# Altium schematic sheet CITest Board_v3.1_A.SchDoc
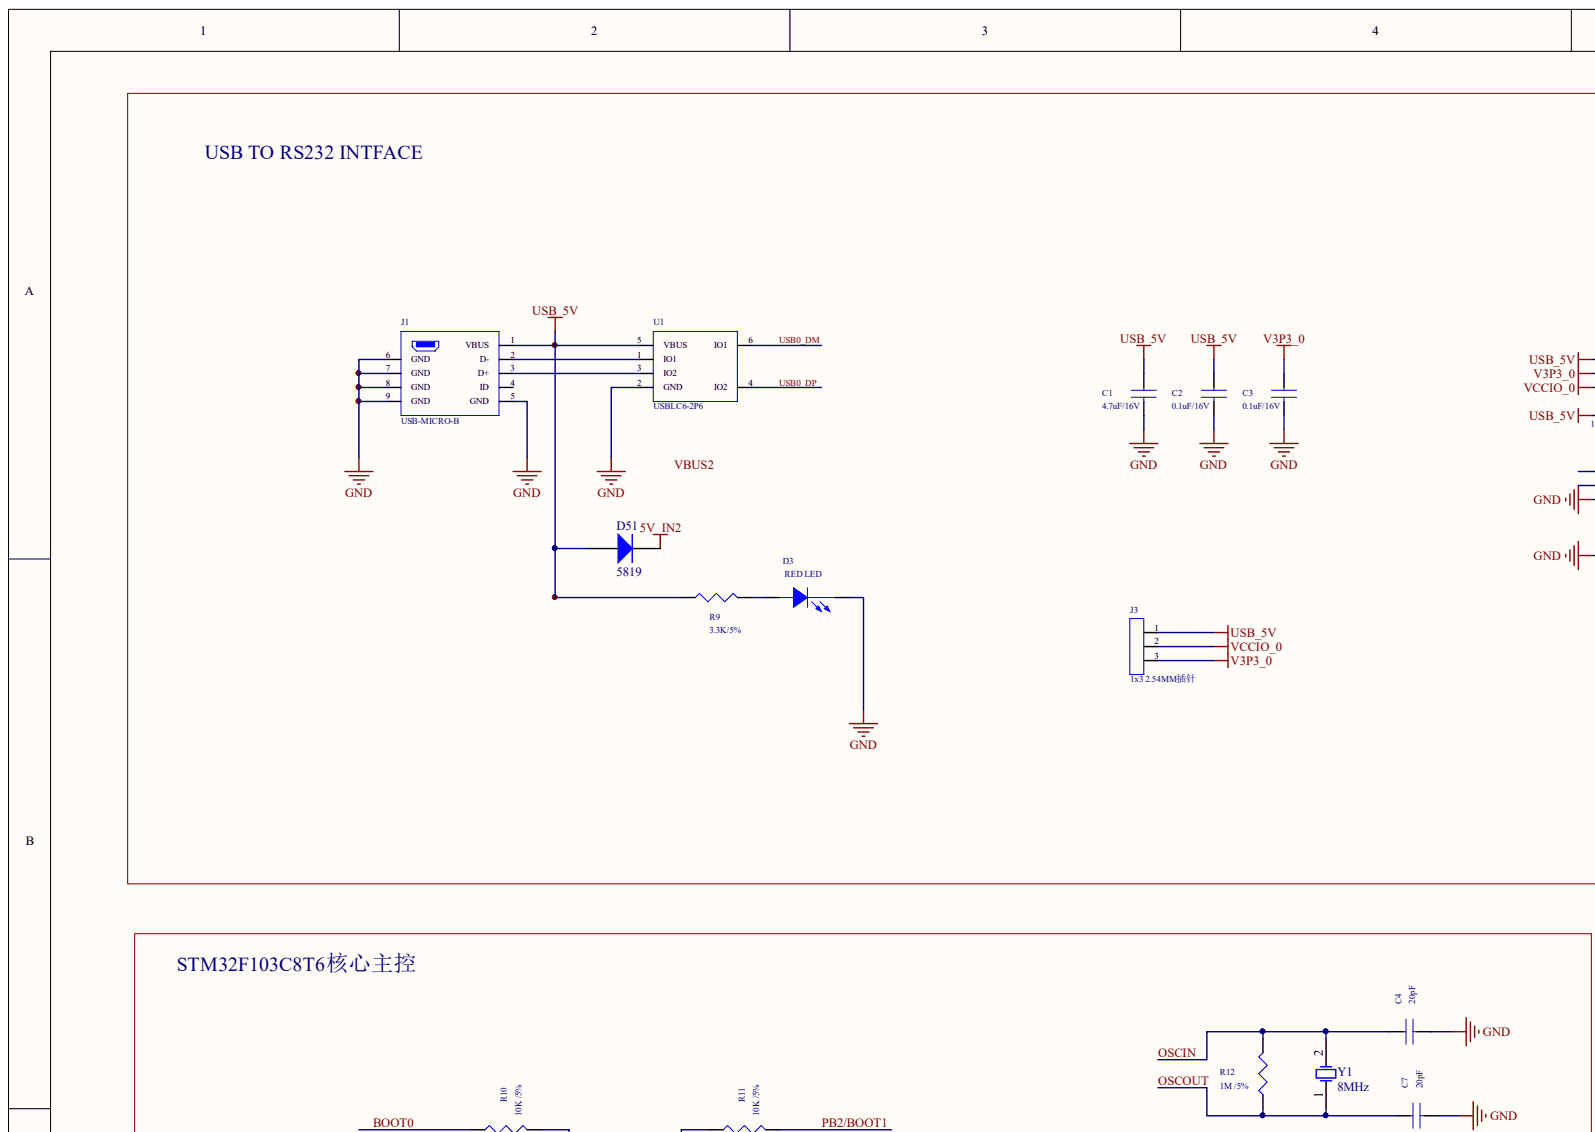
[diagram p0: CITest Board_v3.1_A.SchDoc, top left]
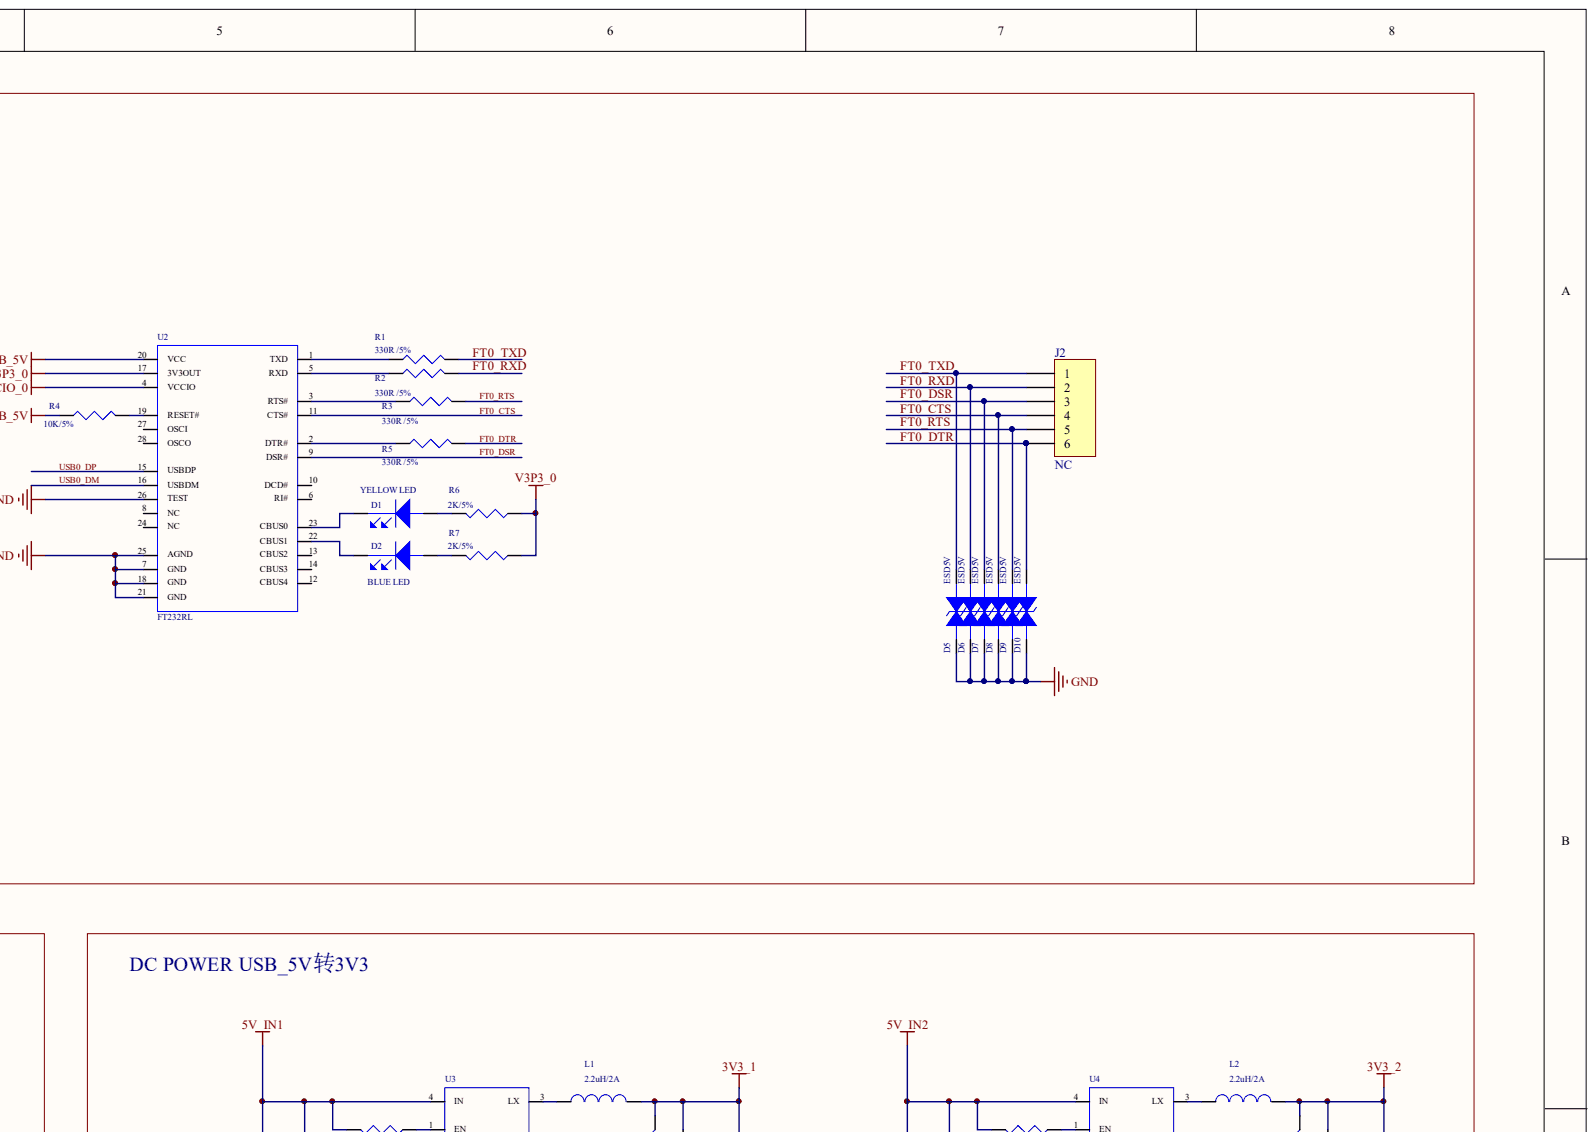
[diagram p1: CITest Board_v3.1_A.SchDoc, top right]
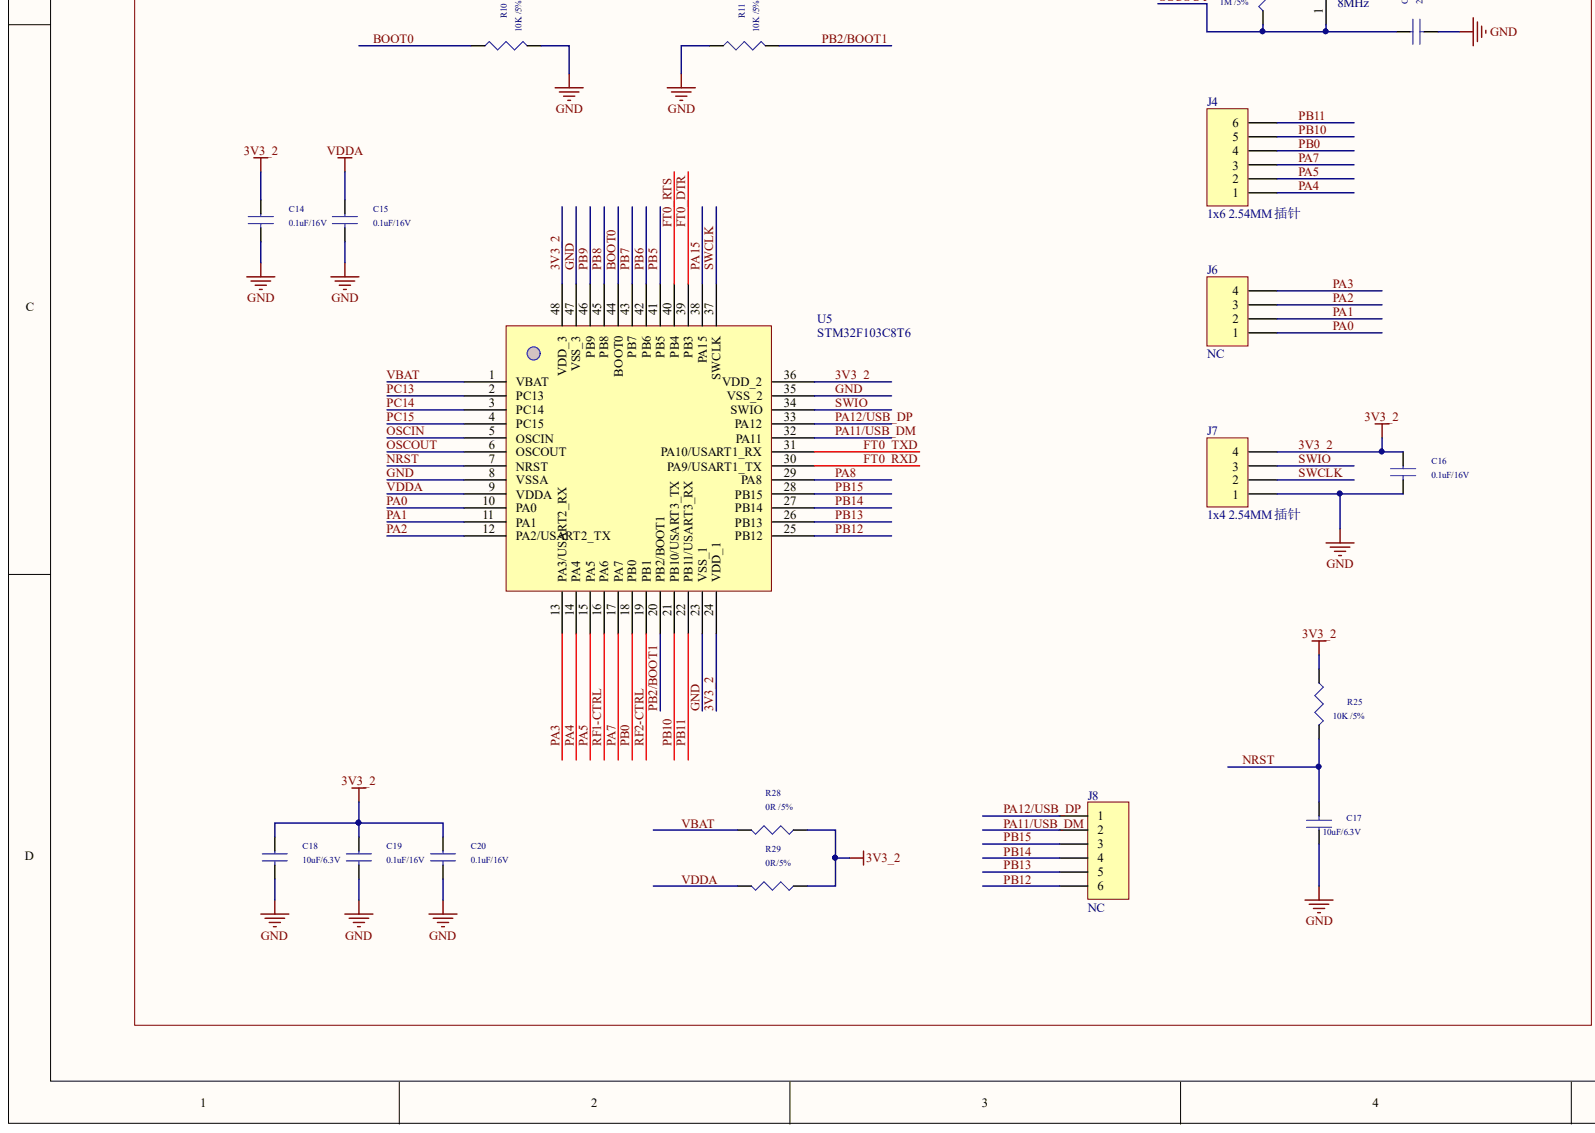
[diagram p2: CITest Board_v3.1_A.SchDoc, bottom left]
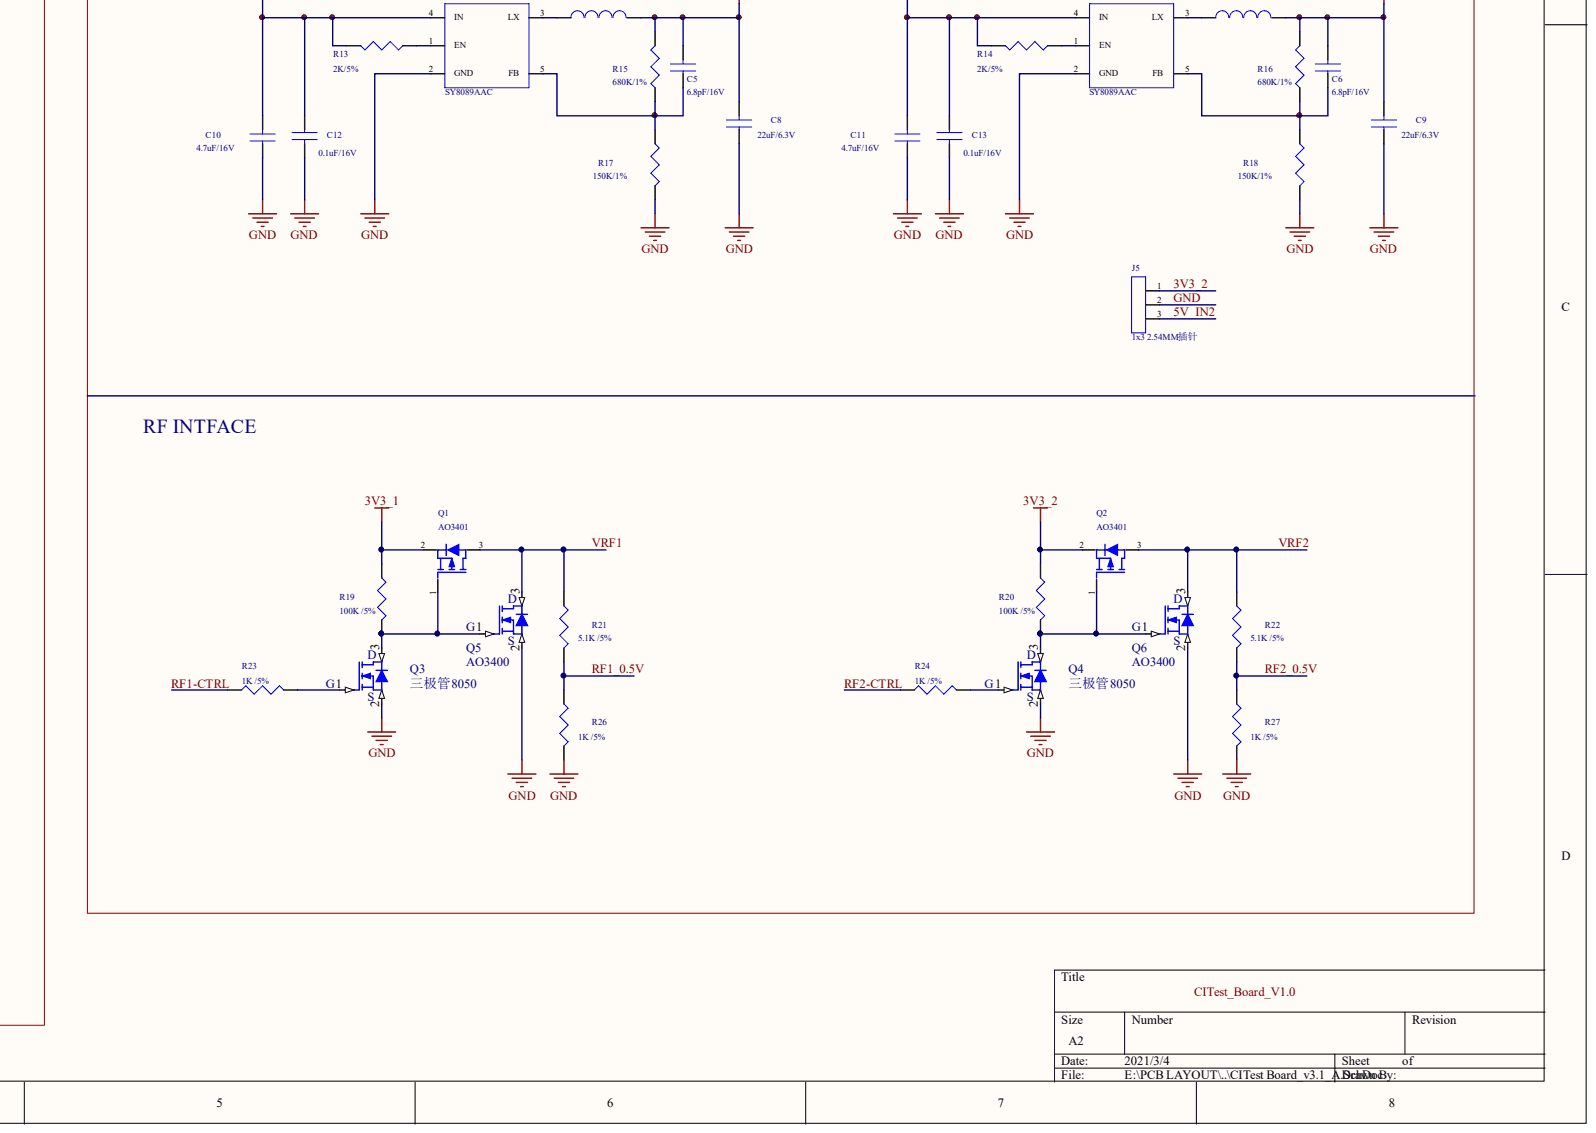
[diagram p3: CITest Board_v3.1_A.SchDoc, bottom right]

## Parts
C1  4.7uF/16V
C2  0.1uF/16V
C3  0.1uF/16V
C4  20pF
C5  6.8pF/16V
C6  6.8pF/16V
C7  20pF
C8  22uF/6.3V
C9  22uF/6.3V
C10  4.7uF/16V
C11  4.7uF/16V
C12  0.1uF/16V
C13  0.1uF/16V
C14  0.1uF/16V
C15  0.1uF/16V
C16  0.1uF/16V
C17  10uF/6.3V
C18  10uF/6.3V
C19  0.1uF/16V
C20  0.1uF/16V
D1  YELLOW LED
D2  BLUE LED
D3  RED LED
D5  ESD5V
D6  ESD5V
D7  ESD5V
D8  ESD5V
D9  ESD5V
D10  ESD5V
D51  5819
J1  USB-MICRO-B
J2  NC
J3  1x3 2.54MM插针
J4  1x6 2.54MM插针
J5  1x3 2.54MM插针
J6  NC
J7  1x4 2.54MM插针
J8  NC
L1  2.2uH/2A
L2  2.2uH/2A
Q1  AO3401
Q2  AO3401
Q3  三极管8050
Q4  三极管8050
Q5  AO3400
Q6  AO3400
R1  330R /5%
R2  330R /5%
R3  330R /5%
R4  10K/5%
R5  330R /5%
R6  2K/5%
R7  2K/5%
R9  3.3K/5%
R10  10K /5%
R11  10K /5%
R12  1M /5%
R13  2K/5%
R14  2K/5%
R15  680K/1%
R16  680K/1%
R17  150K/1%
R18  150K/1%
R19  100K /5%
R20  100K /5%
R21  5.1K /5%
R22  5.1K /5%
R23  1K /5%
R24  1K /5%
R25  10K /5%
R26  1K /5%
R27  1K /5%
R28  0R /5%
R29  0R/5%
U1  USBLC6-2P6
U2  FT232RL
U3  SY8089AAC
U4  SY8089AAC
U5  STM32F103C8T6
Y1  8MHz

## Nets
3V3_1: C5.2 C8.2 L1.1 Q1.2 R15.1 R19.2
5V_IN1: C10.2 C12.1 R13.1 U3.4(IN)
USB_5V: C1.1 C2.1 D51.1 J1.1(VBUS) J3.1 R4.1 R9.2 U1.5(VBUS) U2.20(VCC)
V3P3_0: C3.1 J3.3 R6.1 R7.1 U2.17(3V3OUT)
VCCIO_0: J3.2 U2.4(VCCIO)
3V3_2: C6.2 C9.2 C14.1 C16.1 C18.1 C19.1 C20.1 J5.1 J7.4 L2.1 Q2.2 R16.1 R20.2 R25.2 R28.2 R29.2 U5.24(VDD_1) U5.36(VDD_2) U5.48(VDD_3)
5V_IN2: C11.2 C13.1 D51.2 J5.3 R14.1 U4.4(IN)
BOOT0: R10.2 U5.44(BOOT0)
FT0_CTS: D8.2 J2.4 U2.11(CTS#)
FT0_DSR: D7.2 J2.3 U2.9(DSR#)
FT0_DTR: D10.2 J2.6 R5.1 U5.39(PB3)
FT0_RTS: D9.2 J2.5 R3.1 U5.40(PB4)
FT0_RXD: D6.2 J2.2 R2.1 U5.30(PA9/USART1_TX)
FT0_TXD: D5.2 J2.1 R1.1 U5.31(PA10/USART1_RX)
GND: C1.2 C2.2 C3.2 C4.2 C7.2 C8.1 C9.1 C10.1 C11.1 C12.2 C13.2 C14.2 C15.2 C16.2 C17.1 C18.2 C19.2 C20.2 D3.2 D5.1 D6.1 D7.1 D8.1 D9.1 D10.1 J1.5(GND) J1.6(GND) J1.7(GND) J1.8(GND) J1.9(GND) J5.2 J7.1 Q3.2 Q4.2 Q5.2 Q6.2 R10.1 R11.2 R17.1 R18.1 R26.1 R27.1 U1.2(GND) U2.7(GND) U2.18(GND) U2.21(GND) U2.25(AGND) U2.26(TEST) U3.2(GND) U4.2(GND) U5.8(VSSA) U5.23(VSS_1) U5.35(VSS_2) U5.47(VSS_3)
NRST: C17.2 R25.1 U5.7(NRST)
OSCIN: C4.1 R12.2 U5.5(OSCIN) Y1.2
OSCOUT: C7.1 R12.1 U5.6(OSCOUT) Y1.1
PA0: J6.1 U5.10(PA0)
PA1: J6.2 U5.11(PA1)
PA2: J6.3 U5.12(PA2/USART2_TX)
PA3: J6.4 U5.13(PA3/USART2_RX)
PA4: J4.1 U5.14(PA4)
PA5: J4.2 U5.15(PA5)
PA7: J4.3 U5.17(PA7)
PA8: U5.29(PA8)
PA11/USB_DM: J8.2 U5.32(PA11)
PA12/USB_DP: J8.1 U5.33(PA12)
PA15: U5.38(PA15)
PB0: J4.4 U5.18(PB0)
PB2/BOOT1: R11.1 U5.20(PB2/BOOT1)
PB5: U5.41(PB5)
PB6: U5.42(PB6)
PB7: U5.43(PB7)
PB8: U5.45(PB8)
PB9: U5.46(PB9)
PB10: J4.5 U5.21(PB10/USART3_TX)
PB11: J4.6 U5.22(PB11/USART3_RX)
PB12: J8.6 U5.25(PB12)
PB13: J8.5 U5.26(PB13)
PB14: J8.4 U5.27(PB14)
PB15: J8.3 U5.28(PB15)
PC13: U5.2(PC13)
PC14: U5.3(PC14)
PC15: U5.4(PC15)
RF1-CTRL: R23.2 U5.16(PA6)
RF1_0.5V: R21.1 R26.2
RF2-CTRL: R24.2 U5.19(PB1)
RF2_0.5V: R22.1 R27.2
SWCLK: J7.2 U5.37(SWCLK)
SWIO: J7.3 U5.34(SWIO)
USB0_DM: U1.6(IO1) U2.16(USBDM)
USB0_DP: U1.4(IO2) U2.15(USBDP)
VBAT: R28.1 U5.1(VBAT)
VDDA: C15.1 R29.1 U5.9(VDDA)
VRF1: Q1.3 Q5.3 R21.2
VRF2: Q2.3 Q6.3 R22.2
NetC5_1: C5.1 R15.2 R17.2 U3.5(FB)
NetC6_1: C6.1 R16.2 R18.2 U4.5(FB)
NetD1_1: D1.1 R6.2
NetD1_2: D1.2 U2.23(CBUS0)
NetD2_1: D2.1 R7.2
NetD2_2: D2.2 U2.22(CBUS1)
NetD3_1: D3.1 R9.1
NetJ1_2: J1.2(D-) U1.1(IO1)
NetJ1_3: J1.3(D+) U1.3(IO2)
NetL1_2: L1.2 U3.3(LX)
NetL2_2: L2.2 U4.3(LX)
NetQ1_1: Q1.1 Q3.3 Q5.1 R19.1
NetQ2_1: Q2.1 Q4.3 Q6.1 R20.1
NetQ3_1: Q3.1 R23.1
NetQ4_1: Q4.1 R24.1
NetR1_2: R1.2 U2.1(TXD)
NetR2_2: R2.2 U2.5(RXD)
NetR3_2: R3.2 U2.3(RTS#)
NetR4_2: R4.2 U2.19(RESET#)
NetR5_2: R5.2 U2.2(DTR#)
NetR13_2: R13.2 U3.1(EN)
NetR14_2: R14.2 U4.1(EN)
CITEST_BOARD_V1.0:
N00014:
VBUS2:

Not connected: J1 4(ID); U2 6(RI#) 8(NC) 10(DCD#) 12(CBUS4) 13(CBUS2) 14(CBUS3) 24(NC) 27(OSCI) 28(OSCO)

## Library data of the parts
C1 C8-C11 = (no symbol name): PCB DECAL: C0603; Source_Path: C:\ORCAD\ORCAD_10.5\TOOLS\CAPTURE\LIBRARY\DISCRETE.OLB
  C1 C10 C11: Value: 4.7uF/16V
  C8 C9: Value: 22uF/6.3V
C2-C7 C12-C20 = (no symbol name): PCB DECAL: C0402; Source_Path: C:\ORCAD\ORCAD_10.5\TOOLS\CAPTURE\LIBRARY\DISCRETE.OLB
  C2 C3 C12-C16 C19 C20: Value: 0.1uF/16V
  C4 C7: Value: 20pF
  C5 C6: Value: 6.8pF/16V
  C17 C18: Value: 10uF/6.3V
D1 D2 = (no symbol name): PCB DECAL: LED0603; Source_Path: D:\PROJECT\ESPRESSIF_PROJECT\#10444_ESP_FACTORY TEST BOARD FOR MODULE_V1.4_N_XX_20190408_V0.4\01_SCHEMATIC\ESP_FACTORY TEST BOARD FOR MODULE_V1.4_20190408.DSN
  D1: Value: YELLOW LED
  D2: Value: BLUE LED
D3 = (no symbol name): PCB DECAL: LED0603; Source_Path: G:\WP\PROJECT\RF BOARD\RF TEST CHIP\WIFI_TESTCHIP_BOARD.DSN; Value: RED LED
D5-D10 = (no symbol name): PCB DECAL: SOD-523; Source_Path: E:\PROJECT_NOW\ALMID03_LIBRARY.OLB; Value: ESD5V
J1 = (no symbol name): PCB DECAL: USB-5P-MICROB; Source_Path: D:\YUXUFENG\ESPPROJECT\#30258-FACTORY_TESTBOARD\01_SCH\ESP_FACTORY_TESTBOARD.DSN; Value: USB-MICRO-B
J3 J5 = (no symbol name): PCB DECAL: CON3-2P54; Source_Path: D:\YUXUFENG\ESPPROJECT\#30258-FACTORY_TESTBOARD\01_SCH\ESP_FACTORY_TESTBOARD.DSN; Value: 1x3 2.54MM插针
L1 L2 = (no symbol name): PCB DECAL: LPS4018; Source_Path: C:\ORCAD\ORCAD_10.5\TOOLS\CAPTURE\LIBRARY\DISCRETE.OLB; Value: 2.2uH/2A
Q1 Q2 = (no symbol name): PCB DECAL: SOT-23-MOS; Comment_1: PMOS
R1-R7 R9-R29 = (no symbol name): PCB DECAL: R0402; Source_Path: E:\LI LELE\PROJ_LLL\NEW F BOARD\ESP8266 EVALUATION BOARD\ESP8266 EVALUATION BOARD.DSN
  R1-R3 R5: Value: 330R /5%
  R4: Value: 10K/5%
  R6 R7 R13 R14: Value: 2K/5%
  R9: Value: 3.3K/5%
  R10 R11 R25: Value: 10K /5%
  R12: Value: 1M /5%
  R15 R16: Value: 680K/1%
  R17 R18: Value: 150K/1%
  R19 R20: Value: 100K /5%
  R21 R22: Value: 5.1K /5%
  R23 R24 R26 R27: Value: 1K /5%
  R28: Value: 0R /5%
  R29: Value: 0R/5%
U1 = (no symbol name): PCB DECAL: SOT-666; Source_Path: D:\YUXUFENG\ESPPROJECT\#30258-FACTORY_TESTBOARD\01_SCH\ESP_FACTORY_TESTBOARD.DSN; Value: USBLC6-2P6
U2 = (no symbol name): PCB DECAL: SSOP28-5P3; Source_Path: D:\YUXUFENG\ESPPROJECT\#30258-FACTORY_TESTBOARD\01_SCH\ESP_FACTORY_TESTBOARD.DSN; Value: FT232RL
U3 U4 = (no symbol name): PCB DECAL: SOT-23-5; Source_Path: D:\YUXUFENG\ESPPROJECT\#30258-FACTORY_TESTBOARD\01_SCH\ESP_FACTORY_TESTBOARD.DSN; Value: SY8089AAC
D51 J2 J4 J6-J8 Q3-Q6 U5 Y1 = (no symbol name)
  D51: ComponentLink1Description: 供应商链接; ComponentLink1URL: http://www.szlcsc.com/product/details_2485.html; Package: SOD-323; Supplier: LC; SuppliersPartNumber: C2128
  J2 J4 J6-J8: LatestRevisionDate: 17-Jul-2002; LatestRevisionNote: Re-released for DXP Platform.
  Y1: Published: 8-Jun-2000; LatestRevisionDate: 17-Jul-2002; LatestRevisionNote: Re-released for DXP Platform.
  J2 J4 J6-J8 Y1: Publisher: Altium Limited
